# Altium schematic sheet Top.SchDoc
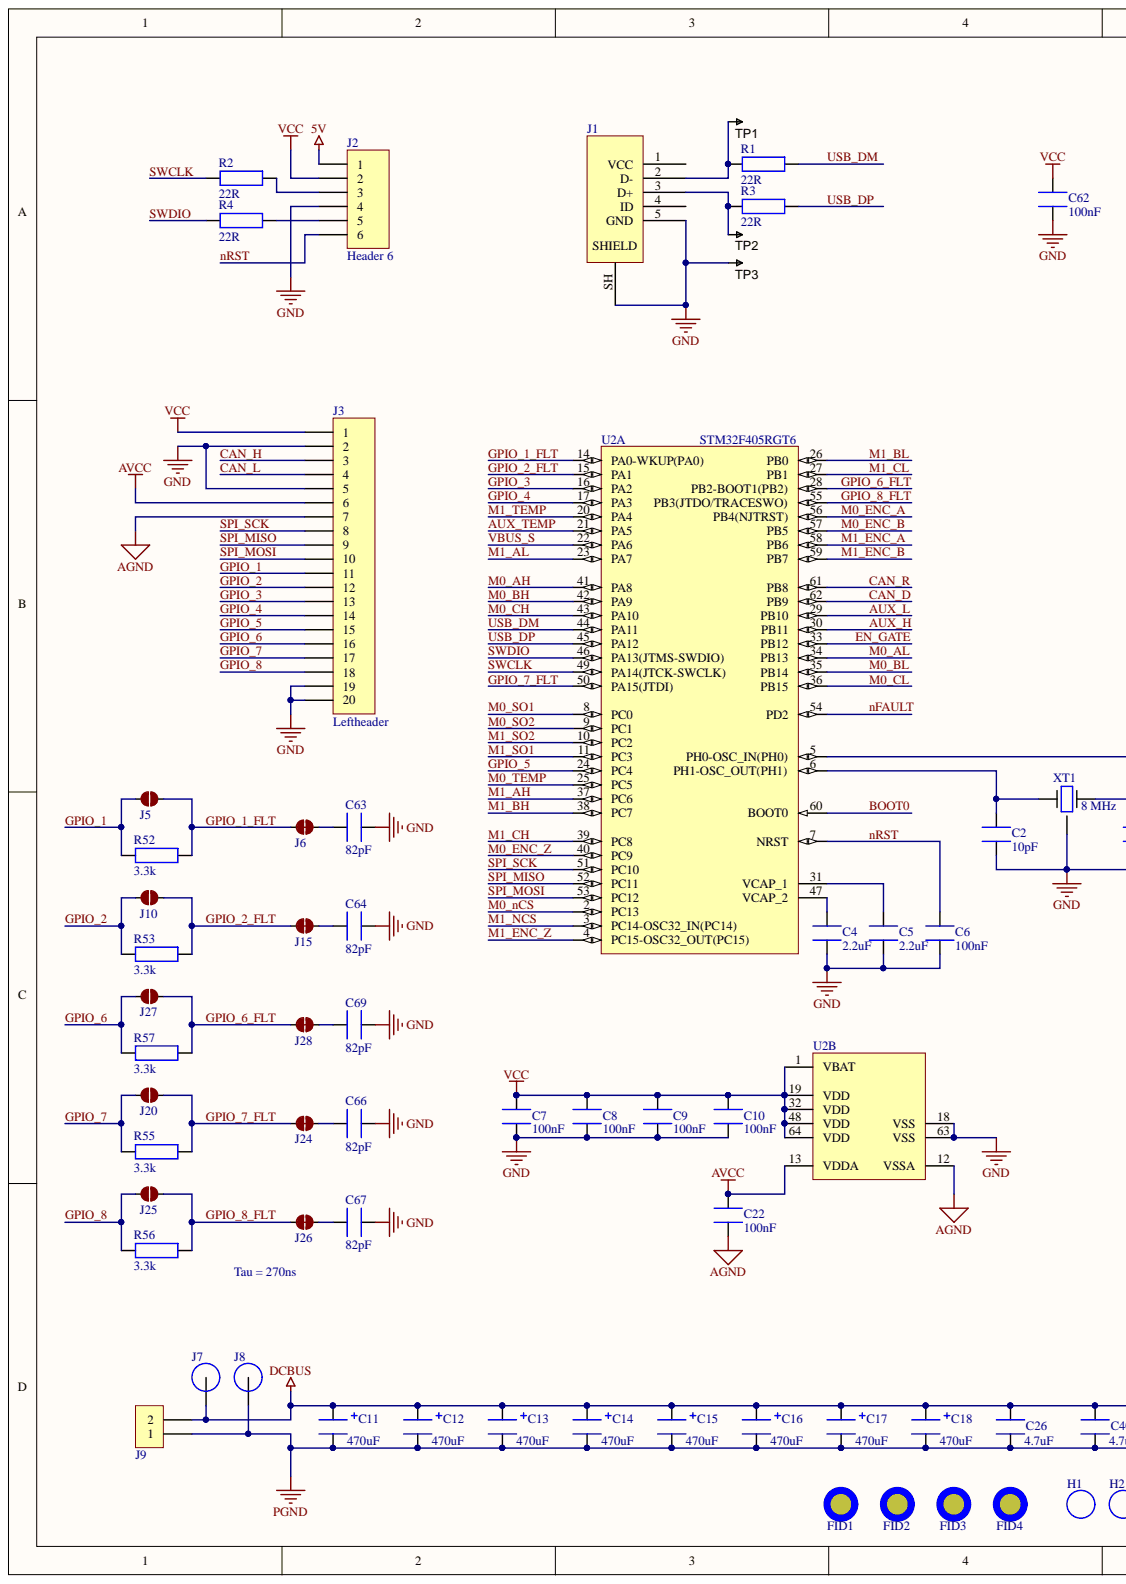
[diagram p0: Top.SchDoc, left]
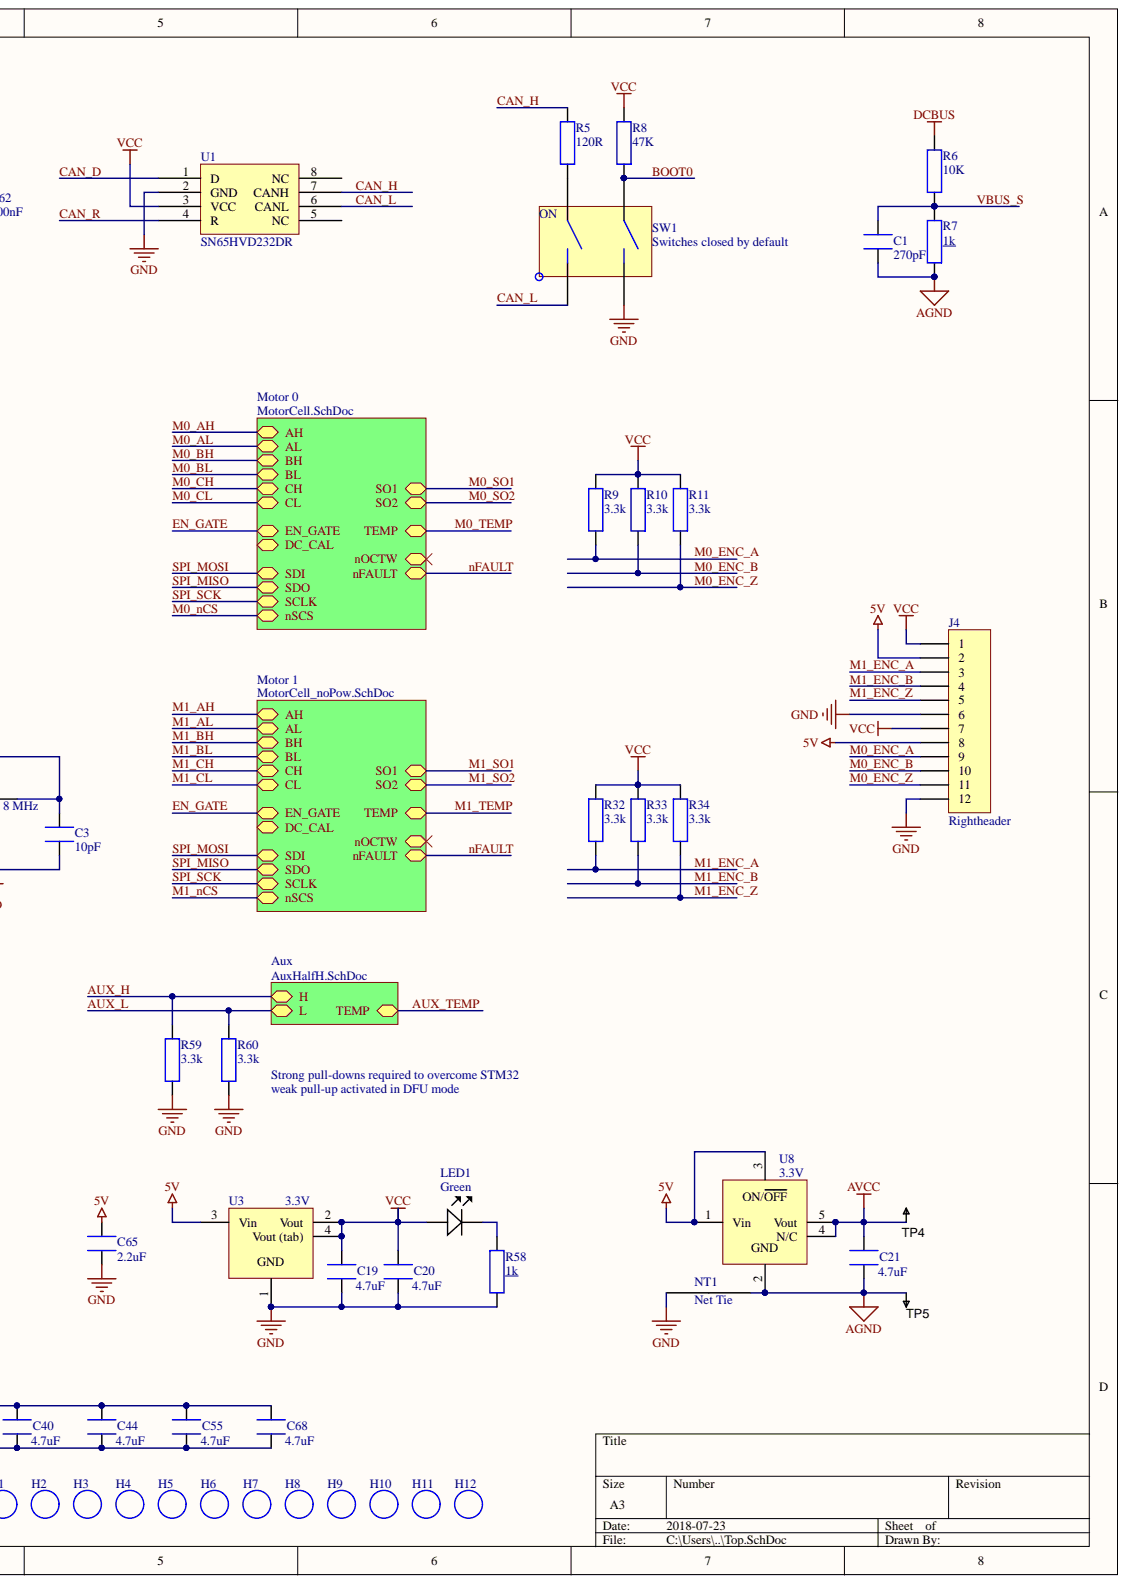
[diagram p1: Top.SchDoc, right]

## Parts
C1  270pF  [CAPC1608X09L]
C2  10pF  [CAPC1608X09L]
C3  10pF  [CAPC1608X09L]
C4  2.2uF  [CAPC1608X09L]
C5  2.2uF  [CAPC1608X09L]
C6  100nF  [CAPC1608X09L]
C7  100nF  [CAPC1608X09L]
C8  100nF  [CAPC1608X09L]
C9  100nF  [CAPC1608X09L]
C10  100nF  [CAPC1608X09L]
C11  470uF  [CAP_ELEC_SIZE_G]
C11  120uF  [CAP_ELEC_SIZE_G]  (value not drawn)
C12  470uF  [CAP_ELEC_SIZE_G]
C12  120uF  [CAP_ELEC_SIZE_G]  (value not drawn)
C13  470uF  [CAP_ELEC_SIZE_G]
C13  120uF  [CAP_ELEC_SIZE_G]  (value not drawn)
C14  470uF  [CAP_ELEC_SIZE_G]
C14  120uF  [CAP_ELEC_SIZE_G]  (value not drawn)
C15  470uF  [CAP_ELEC_SIZE_G]
C15  120uF  [CAP_ELEC_SIZE_G]  (value not drawn)
C16  470uF  [CAP_ELEC_SIZE_G]
C16  120uF  [CAP_ELEC_SIZE_G]  (value not drawn)
C17  470uF  [CAP_ELEC_SIZE_G]
C17  120uF  [CAP_ELEC_SIZE_G]  (value not drawn)
C18  470uF  [CAP_ELEC_SIZE_G]
C18  120uF  [CAP_ELEC_SIZE_G]  (value not drawn)
C19  4.7uF  [CAPC2012X09N]
C19  value 4.7uF  [CAPC2012X09N]  (hidden comment: GRM21BR61H475KE51L)
C20  4.7uF  [CAPC2012X09N]
C20  value 4.7uF  [CAPC2012X09N]  (hidden comment: GRM21BR61H475KE51L)
C21  4.7uF  [CAPC2012X09N]
C21  value 4.7uF  [CAPC2012X09N]  (hidden comment: GRM21BR61H475KE51L)
C22  100nF  [CAPC1608X09L]
C26  4.7uF  [CAPC2012X09N]
C26  value 4.7uF  [CAPC2012X09N]  (hidden comment: GRM21BR61H475KE51L)
C40  4.7uF  [CAPC2012X09N]
C40  value 4.7uF  [CAPC2012X09N]  (hidden comment: GRM21BR61H475KE51L)
C44  4.7uF  [CAPC2012X09N]
C44  value 4.7uF  [CAPC2012X09N]  (hidden comment: GRM21BR61H475KE51L)
C55  4.7uF  [CAPC2012X09N]
C55  value 4.7uF  [CAPC2012X09N]  (hidden comment: GRM21BR61H475KE51L)
C62  100nF  [CAPC1608X09L]
C63  82pF  [CAPC1608X09L]
C64  82pF  [CAPC1608X09L]
C65  2.2uF  [CAPC1608X09L]
C66  82pF  [CAPC1608X09L]
C67  82pF  [CAPC1608X09L]
C68  4.7uF  [CAPC2012X09N]
C68  value 4.7uF  [CAPC2012X09N]  (hidden comment: GRM21BR61H475KE51L)
C69  82pF  [CAPC1608X09L]
FID1  FIDUCIAL  [FIDUCIAL]  (value not drawn)
FID2  FIDUCIAL  [FIDUCIAL]  (value not drawn)
FID3  FIDUCIAL  [FIDUCIAL]  (value not drawn)
FID4  FIDUCIAL  [FIDUCIAL]  (value not drawn)
H1  (no value)  [M3 Hole]  (no BOM)
H2  (no value)  [M3 Hole]  (no BOM)
H3  (no value)  [M3 Hole]  (no BOM)
H4  (no value)  [M3 Hole]  (no BOM)
H5  (no value)  [M3 Hole]  (no BOM)
H6  (no value)  [M3 Hole]  (no BOM)
H7  (no value)  [M3 Hole]  (no BOM)
H8  (no value)  [M3 Hole]  (no BOM)
H9  (no value)  [M3 Hole]  (no BOM)
H10  (no value)  [M3 Hole]  (no BOM)
H11  (no value)  [M3 Hole]  (no BOM)
H12  (no value)  [M3 Hole]  (no BOM)
J1  (no value)  [USB_0103594-0001LF]
J2  Header 6  [HDR1X6]
J3  Leftheader  [HDR1X20]
J4  Rightheader  [HDR1X12]
J5  2 way net solder junction - Normally Open  [2waySolder_NO]  (value not drawn)  (no BOM)
J6  2 way net solder junction - Shorted  [2waySolder_SH]  (value not drawn)
J7  (no value)  [M3 Spade Hole]  (no BOM)
J8  (no value)  [M3 Spade Hole]  (no BOM)
J9  1868076  [1868076]  (value not drawn)
J10  2 way net solder junction - Normally Open  [2waySolder_NO]  (value not drawn)  (no BOM)
J15  2 way net solder junction - Shorted  [2waySolder_SH]  (value not drawn)
J20  2 way net solder junction - Normally Open  [2waySolder_NO]  (value not drawn)  (no BOM)
J24  2 way net solder junction - Shorted  [2waySolder_SH]  (value not drawn)
J25  2 way net solder junction - Normally Open  [2waySolder_NO]  (value not drawn)  (no BOM)
J26  2 way net solder junction - Shorted  [2waySolder_SH]  (value not drawn)
J27  2 way net solder junction - Normally Open  [2waySolder_NO]  (value not drawn)  (no BOM)
J28  2 way net solder junction - Shorted  [2waySolder_SH]  (value not drawn)
LED1  Green  [LED-0603-GREEN]
NT1  (no value)  [NetTie_2mm]
R1  22R  [RESC1608X55N]
R2  22R  [RESC1608X55N]
R3  22R  [RESC1608X55N]
R4  22R  [RESC1608X55N]
R5  120R  [RESC1608X55N]
R6  10K  [RESC1608X55N]
R6  18k  [RESC1608X55N]  (value not drawn)
R7  1k  [RESC1608X55N]
R8  47K  [RESC1608X55N]
R9  3.3k  [RESC1608X55N]
R10  3.3k  [RESC1608X55N]
R11  3.3k  [RESC1608X55N]
R32  3.3k  [RESC1608X55N]
R33  3.3k  [RESC1608X55N]
R34  3.3k  [RESC1608X55N]
R52  3.3k  [RESC1608X55N]
R53  3.3k  [RESC1608X55N]
R55  3.3k  [RESC1608X55N]
R56  3.3k  [RESC1608X55N]
R57  3.3k  [RESC1608X55N]
R58  1k  [RESC1608X55N]
R59  3.3k  [RESC1608X55N]
R60  3.3k  [RESC1608X55N]
SW1  Category: Switches  [SOP254P990X405-4N]  (hidden comment: 219-2MSTR)
TP1  TESTPOINT  [TP-R60]  (value not drawn)  (no BOM)
TP2  TESTPOINT  [TP-R60]  (value not drawn)  (no BOM)
TP3  TESTPOINT  [TP-R60]  (value not drawn)  (no BOM)
TP4  TESTPOINT  [TP-R30]  (value not drawn)  (no BOM)
TP5  TESTPOINT  [TP-R30]  (value not drawn)  (no BOM)
U1  SN65HVD232D  Manufacturer Part Number: SN65HVD232DR  [SOIC127P600X175-8N]
U2  (no value)  [STM-LQFP64_N]  (parts A,B)
U3  3.3V  [SOT223]
U8  3.3V  [SOT23-5]
XT1  8 MHz  Load Capacitance: 10pF  [DFN220P320X110-4N]

## Nets
5V: C65.2 J2.1 J4.2 J4.8 U3.3(Vin) U8.1(Vin) U8.3
AGND: C1.1 C21.1 C22.2 J3.7 NT1.2 R7.1 TP5.1 U2.12(VSSA) U8.2
AVCC: C21.2 C22.1 J3.6 TP4.1 U2.13(VDDA) U8.4(N/C) U8.5(Vout)
DCBUS: C11.1 C12.1 C13.1 C14.1 C15.1 C16.1 C17.1 C18.1 C26.2 C40.2 C44.2 C55.2 C68.2 J7.1 J9.2 R6.2
GND: C2.2 C3.2 C4.1 C5.1 C6.1 C7.2 C8.2 C9.2 C10.2 C19.1 C20.1 C62.2 C63.2 C64.2 C65.1 C66.2 C67.2 C69.2 J1.5(GND) J1.SH J2.4 J3.2 J3.5 J3.19 J3.20 J4.6 J4.12 NT1.1 R58.2 R59.2 R60.2 SW1.2 TP3.1 U1.2(GND) U2.18(VSS) U2.63(VSS) U3.1 XT1.G
PGND: C11.2 C12.2 C13.2 C14.2 C15.2 C16.2 C17.2 C18.2 C26.1 C40.1 C44.1 C55.1 C68.1 J8.1 J9.1
VCC: C7.1 C8.1 C9.1 C10.1 C19.2 C20.2 C62.1 J2.2 J3.1 J4.1 J4.7 LED1.A R8.2 R9.2 R10.2 R11.2 R32.1 R33.1 R34.1 U1.3(VCC) U2.1(VBAT) U2.19(VDD) U2.32(VDD) U2.48(VDD) U2.64(VDD) U3.2(Vout) U3.4(Vout_(tab))
AUX_H: R59.1 U2.30(PB11)
AUX_L: R60.1 U2.29(PB10)
AUX_TEMP: U2.21(PA5)
BOOT0: R8.1 SW1.3 U2.60(BOOT0)
CAN_D: U1.1(D) U2.62(PB9)
CAN_H: J3.3 R5.1 U1.7(CANH)
CAN_L: J3.4 SW1.1 U1.6(CANL)
CAN_R: U1.4(R) U2.61(PB8)
EN_GATE: U2.33(PB12)
GPIO_1: J3.11 J5.1 R52.2
GPIO_1_FLT: J5.2 J6.2 R52.1 U2.14(PA0-WKUP(PA0))
GPIO_2: J3.12 J10.1 R53.2
GPIO_2_FLT: J10.2 J15.2 R53.1 U2.15(PA1)
GPIO_3: J3.13 U2.16(PA2)
GPIO_4: J3.14 U2.17(PA3)
GPIO_5: J3.15 U2.24(PC4)
GPIO_6: J3.16 J27.1 R57.2
GPIO_6_FLT: J27.2 J28.2 R57.1 U2.28(PB2-BOOT1(PB2))
GPIO_7: J3.17 J20.1 R55.2
GPIO_7_FLT: J20.2 J24.2 R55.1 U2.50(PA15(JTDI))
GPIO_8: J3.18 J25.1 R56.2
GPIO_8_FLT: J25.2 J26.2 R56.1 U2.55(PB3(JTDO/TRACESWO))
M0_AH: U2.41(PA8)
M0_AL: U2.34(PB13)
M0_BH: U2.42(PA9)
M0_BL: U2.35(PB14)
M0_CH: U2.43(PA10)
M0_CL: U2.36(PB15)
M0_ENC_A: J4.9 R9.1 U2.56(PB4(NJTRST))
M0_ENC_B: J4.10 R10.1 U2.57(PB5)
M0_ENC_Z: J4.11 R11.1 U2.40(PC9)
M0_NCS: U2.2(PC13)
M0_SO1: U2.8(PC0)
M0_SO2: U2.9(PC1)
M0_TEMP: U2.25(PC5)
M1_AH: U2.37(PC6)
M1_AL: U2.23(PA7)
M1_BH: U2.38(PC7)
M1_BL: U2.26(PB0)
M1_CH: U2.39(PC8)
M1_CL: U2.27(PB1)
M1_ENC_A: J4.3 R32.2 U2.58(PB6)
M1_ENC_B: J4.4 R33.2 U2.59(PB7)
M1_ENC_Z: J4.5 R34.2 U2.4(PC15-OSC32_OUT(PC15))
M1_NCS: U2.3(PC14-OSC32_IN(PC14))
M1_SO1: U2.11(PC3)
M1_SO2: U2.10(PC2)
M1_TEMP: U2.20(PA4)
NFAULT: U2.54(PD2)
NRST: C6.2 J2.6 U2.7(NRST)
SPI_MISO: J3.9 U2.52(PC11)
SPI_MOSI: J3.10 U2.53(PC12)
SPI_SCK: J3.8 U2.51(PC10)
SWCLK: R2.2 U2.49(PA14(JTCK-SWCLK))
SWDIO: R4.2 U2.46(PA13(JTMS-SWDIO))
USB_DM: R1.1 U2.44(PA11)
USB_DP: R3.1 U2.45(PA12)
VBUS_S: C1.2 R6.1 R7.2 U2.22(PA6)
NetC2_1: C2.1 U2.6(PH1-OSC_OUT(PH1)) XT1.1
NetC3_1: C3.1 U2.5(PH0-OSC_IN(PH0)) XT1.3
NetC4_2: C4.2 U2.47(VCAP_2)
NetC5_2: C5.2 U2.31(VCAP_1)
NetC63_1: C63.1 J6.1
NetC64_1: C64.1 J15.1
NetC66_1: C66.1 J24.1
NetC67_1: C67.1 J26.1
NetC69_1: C69.1 J28.1
NetJ1_1: J1.1(VCC)
NetJ1_2: J1.2(D-) R1.2 TP1.1
NetJ1_3: J1.3(D+) R3.2 TP2.1
NetJ1_4: J1.4(ID)
NetJ2_3: J2.3 R2.1
NetJ2_5: J2.5 R4.1
NetLED1_K: LED1.K R58.1
NetR5_2: R5.2 SW1.4
NetU1_5: U1.5(NC)
NetU1_8: U1.8(NC)
N00188:
N00189:
N00190:
N00191:

## Library data of the parts
C1 = GRM1885C1H271JA01D: CAP CER 270PF 50V NP0 0603; Manufacturer: Murata Electronics North America; Temperature Coefficient: C0G, NP0; Tolerance: ±5%; Applications: General Purpose; Capacitance: 270pF; Category: Capacitors; Component Kind: Standard; ComponentLink1Description: http://www.murata.com/~/media/webrenewal/support/library/catalog/products/capacitor/mlcc/c02e.pdf; ComponentLink1URL: http://www.murata.com/~/media/webrenewal/support/library/catalog/products/capacitor/mlcc/c02e.pdf; Features: -; Height - Seated (Max): -; Lead Spacing: -; Lead Style: -; Library Name: CustomComponents.SchLib; Manufacturer Part Number: GRM1885C1H271JA01D; Mounting Type: Surface Mount, MLCC; Operating Temperature: -55°C ~ 125°C; Package / Case: 0603 (1608 Metric); Packaging: Cut Tape (CT); Pricing 1: 1=0.1, 10=0.048, 50=0.026, 100=0.022, 250=0.018, 500=0.0154, 1000=0.012 (USD); Ratings: -; RoHS: RoHS Compliant; Size / Dimension: 0.063" L x 0.031" W (1.60mm x 0.80mm); Stock 1: 46048; Supplier 1: Digi-Key; Supplier Part Number 1: 490-1437-1-ND; Thickness (Max): 0.035" (0.90mm); Voltage - Rated: 50V
C2 C3 = GRM1885C1H100JA01D: CAP CER 10PF 50V NP0 0603; Manufacturer: Murata Electronics North America; Temperature Coefficient: C0G, NP0; Tolerance: ±5%; Applications: General Purpose; Capacitance: 10pF; Category: Capacitors; Component Kind: Standard; ComponentLink1Description: http://www.murata.com/~/media/webrenewal/support/library/catalog/products/capacitor/mlcc/c02e.pdf; ComponentLink1URL: http://www.murata.com/~/media/webrenewal/support/library/catalog/products/capacitor/mlcc/c02e.pdf; Features: -; Height - Seated (Max): -; Lead Spacing: -; Lead Style: -; Library Name: CustomComponents.SchLib; Manufacturer Part Number: GRM1885C1H100JA01D; Mounting Type: Surface Mount, MLCC; Operating Temperature: -55°C ~ 125°C; Package / Case: 0603 (1608 Metric); Packaging: Cut Tape (CT); Pricing 1: 1=0.1, 10=0.041, 50=0.0222, 100=0.0187, 250=0.01532, 500=0.0131, 1000=0.0102 (USD); Ratings: -; RoHS: RoHS Compliant; Size / Dimension: 0.063" L x 0.031" W (1.60mm x 0.80mm); Stock 1: 450321; Supplier 1: Digi-Key; Supplier Part Number 1: 490-1403-1-ND; Thickness (Max): 0.035" (0.90mm); Voltage - Rated: 50V
C4 C5 C65 = EMK107BJ225KA-T: CAP CER 2.2UF 16V X5R 0603; Manufacturer: Taiyo Yuden; Notification: Current market demand for these product types have resulted in fluctuating and extending lead times. Lead times may differ.; Tolerance: ±10%; Applications: General Purpose; Capacitance: 2.2uF; Category: Capacitors; Component Kind: Standard; ComponentLink1Description: http://ds.yuden.co.jp/TYCOMPAS/ut/detail.do?productNo=EMK107BJ225KA-T&fileName=EMK107BJ225KA-T_SS&mode=specSheetDownload; ComponentLink1URL: http://ds.yuden.co.jp/TYCOMPAS/ut/detail.do?productNo=EMK107BJ225KA-T&fileName=EMK107BJ225KA-T_SS&mode=specSheetDownload; Features: -; Height - Seated (Max): -; Lead Spacing: -; Lead Style: -; Library Name: CustomComponents.SchLib; Mounting Type: Surface Mount, MLCC; Operating Temperature: -55°C ~ 85°C; Package / Case: 0603 (1608 Metric); Packaging: Cut Tape (CT); Part Status: Active; Pricing 1: 1=0.12, 10=0.089, 100=0.0396, 500=0.02826, 1000=0.0222 (USD); Ratings: -; RoHS: RoHS Compliant; Size / Dimension: 0.063" L x 0.032" W (1.60mm x 0.80mm); Stock: 1577703; Supplier 1: Digi-Key; Supplier Part Number 1: 587-1430-1-ND; Temperature Coefficient: X5R; Thickness (Max): 0.035" (0.90mm); Voltage - Rated: 16V
C6-C10 C22 C62 = GCM188R91E104KA37D: CAP CER 0.1UF 25V X8R 0603; Manufacturer: Murata Electronics North America; Tolerance: ±10%; Applications: Automotive; Capacitance: 100nF; Category: Capacitors; Component Kind: Standard; ComponentLink1Description: http://psearch.en.murata.com/capacitor/product/GCM188R91E104KA37#.pdf; ComponentLink1URL: http://psearch.en.murata.com/capacitor/product/GCM188R91E104KA37#.pdf; Failure Rate: -; Features: -; Height - Seated (Max): -; Lead Spacing: -; Lead Style: -; Library Name: CustomComponents.SchLib; Mounting Type: Surface Mount, MLCC; Operating Temperature: -55°C ~ 150°C; Package / Case: 0603 (1608 Metric); Packaging: Cut Tape (CT); Part Status: Active; Pricing 1: 1=0.21, 10=0.142, 100=0.0719, 500=0.04918, 1000=0.0435 (USD); Ratings: AEC-Q200; RoHS: RoHS Compliant; Size / Dimension: 0.063" L x 0.032" W (1.60mm x 0.80mm); Stock: 6538; Supplier 1: Digi-Key; Supplier Part Number 1: 490-14359-1-ND; Temperature Coefficient: X8R; Thickness (Max): 0.035" (0.90mm); Voltage - Rated: 25V
C11-C18 = EEEFP1E471AP: CAP, FP Series, 470 µF, 25 V, Radial Can - SMD, 10 mm; Manufacturer: Panasonic Electronic Components; Manufacturer Part Number: EEE-FP1E471AP; ESR (Equivalent Series Resistance): 60 mOhm @ 100kHz; Lifetime @ Temp.: 2000 Hrs @ 105°C; Polarization: Polar; Ripple Current - High Frequency: 1.19A @ 100kHz; Ripple Current - Low Frequency: 773.5mA @ 120Hz; Surface Mount Land Size: 0.406" L x 0.406" W (10.30mm x 10.30mm); Tolerance: ±20%; Applications: Automotive; Category: Capacitors; Component Kind: Standard; ComponentLink1Description: http://industrial.panasonic.com/www-cgi/jvcr13pz.cgi?E+PZ+3+ABA0114+EEEFP1E471AP+7+WW; ComponentLink1URL: http://industrial.panasonic.com/www-cgi/jvcr13pz.cgi?E+PZ+3+ABA0114+EEEFP1E471AP+7+WW; Height - Seated (Max): 0.402" (10.20mm); Lead Spacing: -; Library Name: CustomComponents.SchLib; Mounting Type: Surface Mount; Operating Temperature: -55°C ~ 105°C; Package / Case: Radial, Can - SMD; Packaging: Tape & Reel (TR); Part Status: Active; Pricing 1: 500=0.3571, 1000=0.30072, 2500=0.28192, 5000=0.26313, 12500=0.24997, 25000=0.24434 (USD); Pricing 2: 1=0.92, 10=0.702, 100=0.5025 (USD); RoHS: RoHS Compliant; Size / Dimension: 0.394" Dia (10.00mm); Stock: 8000; Supplier 1: Digi-Key; Supplier 2: Digi-Key; Supplier Part Number 1: PCE4440TR-ND; Supplier Part Number 2: PCE4440CT-ND; Value: 470uF
C11-C18 = EEH-ZC1H121P: CAP ALUM POLY HYB 120UF 50V SMD; Manufacturer: Panasonic Electronic Components; ESR (Equivalent Series Resistance): 28 mOhm; Lifetime @ Temp.: 4000 Hrs @ 125°C; Ripple Current - High Frequency: 1.6A @ 100kHz; Ripple Current - Low Frequency: 240mA @ 100Hz; Surface Mount Land Size: 0.406" L x 0.406" W (10.30mm x 10.30mm); Tolerance: ±20%; Type: Hybrid; Applications: Automotive; Capacitance: 120uF; Category: Capacitors; Component Kind: Standard; ComponentLink1Description: https://industrial.panasonic.com/cdbs/www-data/pdf/RDD0000/ABA0000C1234.pdf; ComponentLink1URL: https://industrial.panasonic.com/cdbs/www-data/pdf/RDD0000/ABA0000C1234.pdf; Height - Seated (Max): 0.413" (10.50mm); Lead Spacing: -; Library Name: CustomComponents.SchLib; Manufacturer Part Number: EEH-ZC1H121P; Mounting Type: Surface Mount; Operating Temperature: -55°C ~ 125°C; Package / Case: Radial, Can - SMD; Packaging: Cut Tape (CT); Part Status: Active; Pricing 1: 1=2.18, 10=1.761, 100=1.345 (USD); Pricing 2: 500=0.89108, 1000=0.77969, 2500=0.75184, 5000=0.724, 12500=0.72134 (USD); RoHS: RoHS Compliant; Size / Dimension: 0.394" Dia (10.00mm); Stock: 1939; Supplier 1: Digi-Key; Supplier 2: Digi-Key; Supplier Part Number 1: P19316CT-ND; Supplier Part Number 2: P19316TR-ND
C19-C21 C26 C40 C44 C55 C68 = GRM219R6YA475KA73D: CAP CER 4.7UF 35V X5R 0805; Manufacturer: Murata Electronics North America; Tolerance: ±10%; Applications: General Purpose; Category: Capacitors; Component Kind: Standard; ComponentLink1Description: http://www.murata.com/~/media/webrenewal/support/library/catalog/products/capacitor/mlcc/c02e.ashx?la=en-us; ComponentLink1URL: http://www.murata.com/~/media/webrenewal/support/library/catalog/products/capacitor/mlcc/c02e.ashx?la=en-us; Features: Derating Recommended; Height - Seated (Max): -; Lead Spacing: -; Lead Style: -; Library Name: CustomComponents.SchLib; Manufacturer Part Number: GRM219R6YA475KA73D; Mounting Type: Surface Mount, MLCC; Operating Temperature: -55°C ~ 85°C; Package / Case: 0805 (2012 Metric); Packaging: Tape & Reel (TR); Part Status: Active; Pricing 1: 4000=0.05126, 8000=0.0487, 12000=0.04613 (USD); Pricing 2: 1=0.26, 10=0.177, 100=0.1002, 500=0.07076, 1000=0.06191 (USD); Ratings: -; RoHS: RoHS Compliant; Size / Dimension: 0.079" L x 0.049" W (2.01mm x 1.25mm); Stock: 152000; Supplier 1: Digi-Key; Supplier 2: Digi-Key; Supplier Part Number 1: 490-7208-2-ND; Supplier Part Number 2: 490-7208-1-ND; Temperature Coefficient: X5R; Thickness (Max): 0.037" (0.95mm); Value: 4.7uF; Voltage - Rated: 35V
C19-C21 C26 C40 C44 C55 C68 = GRM21BR61H475KE51L: CAP CER 4.7UF 50V X5R 0805; Manufacturer: Murata Electronics North America; Tolerance: ±10%; Applications: General Purpose; Category: Capacitors; Component Kind: Standard; ComponentLink1Description: http://search.murata.co.jp/Ceramy/image/img/A01X/G101/ENG/GRM21BR61H475KE51-01.pdf; ComponentLink1URL: http://search.murata.co.jp/Ceramy/image/img/A01X/G101/ENG/GRM21BR61H475KE51-01.pdf; Features: -; Height - Seated (Max): -; Lead Spacing: -; Lead Style: -; Library Name: CustomComponents.SchLib; Manufacturer Part Number: GRM21BR61H475KE51L; Mounting Type: Surface Mount, MLCC; Operating Temperature: -55°C ~ 85°C; Package / Case: 0805 (2012 Metric); Packaging: Tape & Reel (TR); Part Status: Active; Pricing 1: 3000=0.08404, 6000=0.07774, 9000=0.07354 (USD); Pricing 2: 1=0.35, 10=0.242, 100=0.145, 500=0.10872, 1000=0.09181 (USD); Ratings: -; RoHS: RoHS Compliant; Size / Dimension: 0.079" L x 0.049" W (2.00mm x 1.25mm); Stock: 15000; Supplier 1: Digi-Key; Supplier 2: Digi-Key; Supplier Part Number 1: 490-10751-2-ND; Supplier Part Number 2: 490-10751-1-ND; Temperature Coefficient: X5R; Thickness (Max): 0.055" (1.40mm); Voltage - Rated: 50V
C63 C64 C66 C67 C69 = GRM1885C2A820JA01D: CAP CER 82PF 100V NP0 0603; Manufacturer: Murata Electronics North America; Temperature Coefficient: C0G, NP0; Tolerance: ±5%; Applications: General Purpose; Capacitance: 82pF; Category: Capacitors; Component Kind: Standard; ComponentLink1Description: http://www.murata.com/~/media/webrenewal/support/library/catalog/products/capacitor/mlcc/c02e.pdf; ComponentLink1URL: http://www.murata.com/~/media/webrenewal/support/library/catalog/products/capacitor/mlcc/c02e.pdf; Features: -; Height - Seated (Max): -; Lead Spacing: -; Lead Style: -; Library Name: CustomComponents.SchLib; Manufacturer Part Number: GRM1885C2A820JA01D; Mounting Type: Surface Mount, MLCC; Operating Temperature: -55°C ~ 125°C; Package / Case: 0603 (1608 Metric); Packaging: Cut Tape (CT); Pricing 1: 1=0.1, 10=0.052, 50=0.028, 100=0.0237, 250=0.01936, 500=0.01656, 1000=0.0129 (USD); Ratings: -; RoHS: RoHS Compliant; Size / Dimension: 0.063" L x 0.031" W (1.60mm x 0.80mm); Stock 1: 58970; Supplier 1: Digi-Key; Supplier Part Number 1: 490-1349-1-ND; Thickness (Max): 0.035" (0.90mm); Voltage - Rated: 100V
FID1-FID4 = FIDUCIAL: 1mm Fiducial with 2mm soldermask, 3mm keepout; Component Kind: Standard; Library Name: CustomComponents.SchLib
H1-H12 = M3 Hole: M3 Hole with no copper; Library Name: CustomComponents.SchLib
J1 = 10103594-0001LF: MICRO USB B, RECEPTACLE, SMT, RA, 5WAY; Component Kind: Standard; Library Name: CustomComponents.SchLib; Supplier 1: Farnell; Supplier Part Number 1: 2293752
J2 = Header 6: Header, 6-Pin; Component Kind: Standard; LatestRevisionDate: 17-Jul-2002; LatestRevisionNote: Re-released for DXP Platform.; Library Name: Miscellaneous Connectors.IntLib; Signal Integrity: Connector; Publisher: Altium Limited
J3 = Header 20: Header, 20-Pin; Component Kind: Standard; LatestRevisionDate: 17-Jul-2002; LatestRevisionNote: Re-released for DXP Platform.; Library Name: Miscellaneous Connectors.IntLib; Signal Integrity: Connector; Publisher: Altium Limited
J4 = Header 12: Header, 12-Pin; Component Kind: Standard; LatestRevisionDate: 17-Jul-2002; LatestRevisionNote: Re-released for DXP Platform.; Library Name: Miscellaneous Connectors.IntLib; Signal Integrity: Connector; Publisher: Altium Limited
J5 J10 J20 J25 J27 = 2waySolderNO: ComponentLink1Description: Source; ComponentLink1URL: http://www.edatools.cz/addons; Description: 2 way net solder junction - Normally Open; Library Name: CustomComponents.SchLib
J6 J15 J24 J26 J28 = 2waySolderSH: Component Kind: Net Tie; ComponentLink1Description: Source; ComponentLink1URL: http://www.edatools.cz/addons; Description: 2 way net solder junction - Shorted; Library Name: CustomComponents.SchLib
J7 J8 = M3 Spade Terminal: Description: M3 Spade Terminal; Library Name: CustomComponents.SchLib
J9 = 1868076: CONN TERM BLOCK 2POS 7.62MM; Manufacturer: Phoenix Contact; Current: 30A; Mating Orientation: Horizontal with Board; Number of Levels: 1; Pitch: 0.300" (7.62mm); Positions Per Level: 2; Screw Thread: M3; Torque - Screw: 0.5-0.6 Nm (4.4-5.3 Lb-In); Voltage: 300V; Wire Gauge: 10-30 AWG; Wire Termination: Screw - Rising Cage Clamp; Category: Connectors, Interconnects; Clamp Material - Plating: -; Color: Green; Component Kind: Standard; ComponentLink1Description: http://media.digikey.com/PDF/Data%20Sheets/Phoenix%20Contact%20PDFs/1868076.pdf; ComponentLink1URL: http://media.digikey.com/PDF/Data%20Sheets/Phoenix%20Contact%20PDFs/1868076.pdf; Contact Material - Plating: -; Features: Interlocking (Side); Housing Material: Polyamide (PA), Nylon; Library Name: CustomComponents.SchLib; Mounting Type: Through Hole; Operating Temperature: -; Packaging: Bulk; Part Status: Active; Pricing 1: 1=1.56, 10=1.517, 50=1.4178, 100=1.3044, 250=1.20512, 500=1.14842, 1000=1.0564 (USD); RoHS: RoHS Compliant; Screw Material - Plating: -; Stock: 2175; Supplier 1: Digi-Key; Supplier Part Number 1: 277-5840-ND
LED1 = LTST-C190KGKT: LED GREEN CLEAR 0603 SMD; Manufacturer: Lite-On Inc.; Current - Test: 20mA; Lens Color: Colorless; Lens Style/Size: Rectangle with Flat Top, 1.10mm x 0.80mm; Millicandela Rating: 35mcd; Viewing Angle: 130°; Voltage - Forward (Vf) (Typ): 2V; Wavelength - Dominant: 571nm; Wavelength - Peak: 574nm; Category: Optoelectronics; Color: Green; Component Kind: Standard; ComponentLink1Description: http://optoelectronics.liteon.com/upload/download/DS22-2000-074/S_110_LTST-C190KGKT.pdf; ComponentLink1URL: http://optoelectronics.liteon.com/upload/download/DS22-2000-074/S_110_LTST-C190KGKT.pdf; Features: -; Height (Max): 0.80mm; Lens Transparency: Clear; Library Name: CustomComponents.SchLib; Manufacturer Part Number: LTST-C190KGKT; Mounting Type: Surface Mount; Package / Case: 0603 (1608 Metric); Packaging: Cut Tape (CT); Part Status: Active; Pricing 1: 1=0.27, 10=0.196, 25=0.1448, 100=0.1034, 250=0.07032, 500=0.06204, 1000=0.0486 (USD); RoHS: RoHS Compliant; Size / Dimension: 1.60mm L x 0.80mm W; Stock: 922756; Supplier 1: Digi-Key; Supplier Part Number 1: 160-1435-1-ND
NT1 = NetTie_2mm: Component Kind: Net Tie; Library Name: CustomComponents.SchLib
R1-R4 = RC0603FR-0722RL: RES SMD 22 OHM 1% 1/10W 0603; Manufacturer: Yageo; Power (Watts): 0.1W, 1/10W; Resistance (Ohms): 22; Temperature Coefficient: ±100ppm/°C; Category: Resistors; Component Kind: Standard; ComponentLink1Description: http://www.yageo.com/documents/recent/PYu-RC_Group_51_RoHS_L_04.pdf; ComponentLink1URL: http://www.yageo.com/documents/recent/PYu-RC_Group_51_RoHS_L_04.pdf; Composition: Thick Film; Features: Moisture Resistant; Height: 0.022" (0.55mm); Library Name: CustomComponents.SchLib; Manufacturer Part Number: RC0603FR-0722RL; Number of Terminations: 2; Operating Temperature: -55°C ~ 155°C; Package / Case: 0603 (1608 Metric); Packaging: Cut Tape (CT); Pricing 1: 1=0.1, 10=0.014, 25=0.01, 100=0.0057, 250=0.00436, 500=0.00348, 1000=0.00257, 2500=0.00223 (USD); RoHS: RoHS Compliant; Size / Dimension: 0.063" L x 0.031" W (1.60mm x 0.80mm); Stock 1: 575303; Supplier 1: Digi-Key; Supplier Device Package: 0603; Supplier Part Number 1: 311-22.0HRCT-ND; Tolerance: ±1%
R5 = RC0603FR-07120RL: RES SMD 120 OHM 1% 1/10W 0603; Manufacturer: Yageo; Power (Watts): 0.1W, 1/10W; Resistance (Ohms): 120; Temperature Coefficient: ±100ppm/°C; Category: Resistors; Component Kind: Standard; ComponentLink1Description: http://www.yageo.com/documents/recent/PYu-RC_Group_51_RoHS_L_04.pdf; ComponentLink1URL: http://www.yageo.com/documents/recent/PYu-RC_Group_51_RoHS_L_04.pdf; Composition: Thick Film; Features: Moisture Resistant; Height: 0.022" (0.55mm); Library Name: CustomComponents.SchLib; Manufacturer Part Number: RC0603FR-07120RL; Number of Terminations: 2; Operating Temperature: -55°C ~ 155°C; Package / Case: 0603 (1608 Metric); Packaging: Cut Tape (CT); Pricing 1: 1=0.1, 10=0.014, 25=0.01, 100=0.0057, 250=0.00436, 500=0.00348, 1000=0.00257, 2500=0.00223 (USD); RoHS: RoHS Compliant; Size / Dimension: 0.063" L x 0.031" W (1.60mm x 0.80mm); Stock 1: 1545282; Supplier 1: Digi-Key; Supplier Device Package: 0603; Supplier Part Number 1: 311-120HRCT-ND; Tolerance: ±1%
R6 = RC0603FR-0710KL: RES SMD 10K OHM 1% 1/10W 0603; Manufacturer: Yageo; Power (Watts): 0.1W, 1/10W; Temperature Coefficient: ±100ppm/°C; Category: Resistors; Component Kind: Standard; ComponentLink1Description: http://www.yageo.com/documents/recent/PYu-RC_Group_51_RoHS_L_04.pdf; ComponentLink1URL: http://www.yageo.com/documents/recent/PYu-RC_Group_51_RoHS_L_04.pdf; Composition: Thick Film; Features: Moisture Resistant; Height: 0.022" (0.55mm); Library Name: CustomComponents.SchLib; Manufacturer Part Number: RC0603FR-0710KL; Number of Terminations: 2; Operating Temperature: -55°C ~ 155°C; Package / Case: 0603 (1608 Metric); Packaging: Cut Tape (CT); Pricing 1: 1=0.1, 10=0.014, 25=0.01, 100=0.0057, 250=0.00436, 500=0.00348, 1000=0.00257, 2500=0.00223 (USD); Resistance (Ohms): 10k; RoHS: RoHS Compliant; Size / Dimension: 0.063" L x 0.031" W (1.60mm x 0.80mm); Stock 1: 13504930; Supplier 1: Digi-Key; Supplier Device Package: 0603; Supplier Part Number 1: 311-10.0KHRCT-ND; Tolerance: ±1%
R6 = RC0603FR-0718KL: RES SMD 18K OHM 1% 1/10W 0603; Manufacturer: Yageo; Power (Watts): 0.1W, 1/10W; Temperature Coefficient: ±100ppm/°C; Category: Resistors; Component Kind: Standard; ComponentLink1Description: http://www.yageo.com/documents/recent/PYu-RC_Group_51_RoHS_L_04.pdf; ComponentLink1URL: http://www.yageo.com/documents/recent/PYu-RC_Group_51_RoHS_L_04.pdf; Composition: Thick Film; Features: Moisture Resistant; Height: 0.022" (0.55mm); Library Name: CustomComponents.SchLib; Manufacturer Part Number: RC0603FR-0718KL; Number of Terminations: 2; Operating Temperature: -55°C ~ 155°C; Package / Case: 0603 (1608 Metric); Packaging: Cut Tape (CT); Pricing 1: 1=0.1, 10=0.014, 25=0.01, 100=0.0057, 250=0.00436, 500=0.00348, 1000=0.00257, 2500=0.00223 (USD); Resistance (Ohms): 18k; RoHS: RoHS Compliant; Size / Dimension: 0.063" L x 0.031" W (1.60mm x 0.80mm); Stock 1: 0; Supplier 1: Digi-Key; Supplier Device Package: 0603; Supplier Part Number 1: 311-18.0KHRCT-ND; Tolerance: ±1%
R7 R58 = RC0603FR-071KL: RES SMD 1K OHM 1% 1/10W 0603; Manufacturer: Yageo; Power (Watts): 0.1W, 1/10W; Temperature Coefficient: ±100ppm/°C; Category: Resistors; Component Kind: Standard; ComponentLink1Description: http://www.yageo.com/documents/recent/PYu-RC_Group_51_RoHS_L_04.pdf; ComponentLink1URL: http://www.yageo.com/documents/recent/PYu-RC_Group_51_RoHS_L_04.pdf; Composition: Thick Film; Features: Moisture Resistant; Height: 0.022" (0.55mm); Library Name: CustomComponents.SchLib; Manufacturer Part Number: RC0603FR-071KL; Number of Terminations: 2; Operating Temperature: -55°C ~ 155°C; Package / Case: 0603 (1608 Metric); Packaging: Cut Tape (CT); Pricing 1: 1=0.1, 10=0.014, 25=0.01, 100=0.0057, 250=0.00436, 500=0.00348, 1000=0.00257, 2500=0.00223 (USD); Resistance (Ohms): 1k; RoHS: RoHS Compliant; Size / Dimension: 0.063" L x 0.031" W (1.60mm x 0.80mm); Stock 1: 6734836; Supplier 1: Digi-Key; Supplier Device Package: 0603; Supplier Part Number 1: 311-1.00KHRCT-ND; Tolerance: ±1%
R8 = RC0603FR-0747KL: RES SMD 47K OHM 1% 1/10W 0603; Manufacturer: Yageo; Power (Watts): 0.1W, 1/10W; Temperature Coefficient: ±100ppm/°C; Category: Resistors; Component Kind: Standard; ComponentLink1Description: http://www.yageo.com/documents/recent/PYu-RC_Group_51_RoHS_L_04.pdf; ComponentLink1URL: http://www.yageo.com/documents/recent/PYu-RC_Group_51_RoHS_L_04.pdf; Composition: Thick Film; Features: Moisture Resistant; Height: 0.022" (0.55mm); Library Name: CustomComponents.SchLib; Manufacturer Part Number: RC0603FR-0747KL; Number of Terminations: 2; Operating Temperature: -55°C ~ 155°C; Package / Case: 0603 (1608 Metric); Packaging: Cut Tape (CT); Pricing 1: 1=0.1, 10=0.014, 25=0.01, 100=0.0057, 250=0.00436, 500=0.00348, 1000=0.00257, 2500=0.00223 (USD); Resistance (Ohms): 47k; RoHS: RoHS Compliant; Size / Dimension: 0.063" L x 0.031" W (1.60mm x 0.80mm); Stock 1: 930868; Supplier 1: Digi-Key; Supplier Device Package: 0603; Supplier Part Number 1: 311-47.0KHRCT-ND; Tolerance: ±1%
R9-R11 R32-R34 R52 R53 R55-R57 R59 R60 = RC0603FR-073K3L: RES SMD 3.3K OHM 1% 1/10W 0603; Manufacturer: Yageo; Power (Watts): 0.1W, 1/10W; Temperature Coefficient: ±100ppm/°C; Category: Resistors; Component Kind: Standard; ComponentLink1Description: http://www.yageo.com/documents/recent/PYu-RC_Group_51_RoHS_L_04.pdf; ComponentLink1URL: http://www.yageo.com/documents/recent/PYu-RC_Group_51_RoHS_L_04.pdf; Composition: Thick Film; Features: Moisture Resistant; Height: 0.022" (0.55mm); Library Name: CustomComponents.SchLib; Manufacturer Part Number: RC0603FR-073K3L; Number of Terminations: 2; Operating Temperature: -55°C ~ 155°C; Package / Case: 0603 (1608 Metric); Packaging: Cut Tape (CT); Pricing 1: 1=0.1, 10=0.014, 25=0.01, 100=0.0057, 250=0.00436, 500=0.00348, 1000=0.00257, 2500=0.00223 (USD); Resistance (Ohms): 3.3k; RoHS: RoHS Compliant; Size / Dimension: 0.063" L x 0.031" W (1.60mm x 0.80mm); Stock 1: 420019; Supplier 1: Digi-Key; Supplier Device Package: 0603; Supplier Part Number 1: 311-3.30KHRCT-ND; Tolerance: ±1%
SW1 = 219-2MSTR: SWITCH SLIDE DIP SPST 100MA 20V; Manufacturer: CTS Electrocomponents; Actuator Level: Raised; Actuator Type: Slide (Standard); Electrical Life: 2,000 Cycles; Pitch: 0.100" (2.54mm), Full; Voltage Rating: 20VDC; Washable: Yes; Body Material: -; Circuit: SPST; Component Kind: Standard; ComponentLink1Description: https://www.ctscorp.com/wp-content/uploads/219.pdf; ComponentLink1URL: https://www.ctscorp.com/wp-content/uploads/219.pdf; Contact Finish: Gold; Contact Material: Beryllium Copper; Current Rating: 100mA; Features: Epoxy Sealed Terminals, Tape Seal; Height Above Board: 0.170" (4.33mm); Length: 0.258" (6.55mm); Library Name: CustomComponents.SchLib; Mechanical Life: -; Mounting Type: Surface Mount; Operating Temperature: -55°C ~ 85°C; Packaging: Cut Tape (CT); Part Status: Active; Pricing 1: 1=0.73, 10=0.718, 25=0.6764, 50=0.6348, 100=0.6072, 250=0.5796, 500=0.5244 (USD); RoHS: RoHS Compliant; Stock: 9967; Supplier 1: Digi-Key; Supplier Part Number 1: CT3114CT-ND; Termination Style: Gull Wing
TP1-TP5 = TESTPOINT: SMD test pin; Library Name: PBL - Test Points.SCHLIB
U1 = SN65HVD232D: Manufacturer: Texas Instruments; Data Rate: 1Mbps; Duplex: Half; Number of Drivers/Receivers: 1/1; Protocol: CAN; Voltage - Supply: 3 V ~ 3.6 V; Category: Integrated Circuits (ICs); Component Kind: Standard; ComponentLink1Description: http://www.ti.com/lit/ds/symlink/sn65hvd230.pdf; ComponentLink1URL: http://www.ti.com/lit/ds/symlink/sn65hvd230.pdf; Library Name: CustomComponents.SchLib; Mounting Type: Surface Mount; Operating Temperature: -40°C ~ 85°C; Package / Case: 8-SOIC (0.154", 3.90mm Width); Packaging: Tape & Reel (TR); Part Status: Active; Pricing 1: 2500=0.84375 (USD); Pricing 2: 1=2.05, 10=1.842, 100=1.4809, 500=1.21668, 1000=1.00811 (USD); RoHS: RoHS Compliant; Stock: 5000; Supplier 1: Digi-Key; Supplier 2: Digi-Key; Supplier Device Package: 8-SOIC; Supplier Part Number 1: 296-26344-2-ND; Supplier Part Number 2: 296-26344-1-ND; Type: Transceiver
U2 = STM32F405RGT6: ARM Cortex-M4 32-bit MCU+FPU, 210 DMIPS, 1024 kB Flash, 192 kB Internal RAM, 51 I/Os, 64-pin LQFP, -40 to 85 degC, Tray; Manufacturer: STMicroelectronics; D/A Converter: 2x12-bit; FLASH Size (Prog) (kB): 1024; I/Os (High Current): 51; Internal RAM Size (kB): 192; Operating Frequency(F) (Processor speed) (MHz): 168; Supply Voltage(Vcc) max (V): 3.6; Supply Voltage(Vcc) min (V): 1.8; Component Kind: Standard; ComponentLink1Description: Manufacturer URL; ComponentLink1URL: http://www.st.com/web/en/home.html; ComponentLink2Description: Datasheet; ComponentLink2URL: http://www.st.com/st-web-ui/static/active/en/resource/technical/document/datasheet/DM00037051.pdf; ComponentLink3Description: Package Specification; ComponentLink3URL: http://www.st.com/st-web-ui/static/active/en/resource/technical/document/datasheet/DM00037051.pdf; Core: ARM Cortex-M4; DatasheetVersion: Rev. 4; Library Name: STMicroelectronics STM32 F4.IntLib; Mounting Technology: Surface Mount; PackageDescription: 64-Pin Low Profile Quad Flat Package, 10 x 10 mm Body, 0.5 mm Pitch; PackageReference: LQFP64; PackageVersion: Rev. 4, 06/2013; Packing: Tray; PartNumber: STM32F405RGT6; Supplier 1: Digi-Key; Supplier 2: Farnell; Supplier 3: Newark; Supplier 4: Mouser; Supplier Part Number 1: 497-11767-ND; Supplier Part Number 2: 2064363; Supplier Part Number 3: 73T2329; Supplier Part Number 4: 511-STM32F405RGT6
U3 = AZ1117EH-3.3TRG1: IC REG LDO 3.3V 1A SOT223; Manufacturer: Diodes Incorporated; Regulator Topology: Positive Fixed; Voltage - Dropout (Typical): 1.1V @ 1A; Voltage - Input: Up to 13V; Category: Integrated Circuits (ICs); Component Kind: Standard; ComponentLink1Description: http://www.diodes.com/assets/Datasheets/AZ1117E.pdf; ComponentLink1URL: http://www.diodes.com/assets/Datasheets/AZ1117E.pdf; Current - Limit (Min): -; Current - Output: 1A; Library Name: CustomComponents.SchLib; Mounting Type: Surface Mount; Operating Temperature: -40°C ~ 125°C; Package / Case: TO-261-4, TO-261AA; Packaging: Cut Tape (CT); Part Status: Active; Pricing 1: 1=0.43, 10=0.321, 100=0.1815, 500=0.12022, 1000=0.09216 (USD); RoHS: RoHS Compliant; Stock 1: 22748; Supplier 1: Digi-Key; Supplier Device Package: SOT-223; Supplier Part Number 1: AZ1117EH-3.3TRG1DICT-ND; Voltage - Output: 3.3V
U8 = LP5907MFX-3.3/NOPB: IC REG LDO 3.3V 0.25A SOT23-5; Manufacturer: Texas Instruments; Current - Output: 250mA; Regulator Topology: Positive Fixed; Voltage - Input: Up to 5.5V; Category: Integrated Circuits (ICs); Component Kind: Standard; ComponentLink1Description: http://www.ti.com/lit/ds/symlink/lp5907.pdf; ComponentLink1URL: http://www.ti.com/lit/ds/symlink/lp5907.pdf; Current - Limit (Min): -; Library Name: CustomComponents.SchLib; Mounting Type: Surface Mount; Operating Temperature: -40°C ~ 125°C; Package / Case: SC-74A, SOT-753; Packaging: Cut Tape (CT); Part Status: Active; Pricing 1: 1=0.57, 10=0.487, 100=0.3634, 500=0.28552, 1000=0.22063 (USD); RoHS: RoHS Compliant; Stock 1: 39845; Supplier 1: Digi-Key; Supplier Device Package: SOT-23; Supplier Part Number 1: 296-38557-1-ND; Voltage - Dropout (Typical): -; Voltage - Output: 3.3V
XT1 = ABM3B-8.000MHZ-10-1-U-T: Crystal 8MHz ±10ppm 10pF 200 Ohm -10°C ~ 60°C Surface Mount 4-SMD, No Lead (DFN, LCC); Manufacturer: Abracon LLC; Operating Mode: Fundamental; Category: Crystals, Oscillators, Resonators; Component Kind: Standard; ComponentLink1Description: http://www.abracon.com/Resonators/abm3b.pdf; ComponentLink1URL: http://www.abracon.com/Resonators/abm3b.pdf; Frequency Stability: ±10ppm; Frequency Tolerance: ±10ppm; Height - Seated (Max): 0.043" (1.10mm); Library Name: CustomComponents.SchLib; Manufacturer Part Number: ABM3B-8.000MHZ-10-1-U-T; Mounting Type: Surface Mount; Operating Temperature: -10°C ~ 60°C; Package / Case: 4-SMD, No Lead; Packaging: Cut Tape (CT); Part Status: Active; Pricing 1: 1=0.69, 10=0.578, 50=0.5198, 100=0.462, 500=0.4389 (USD); Pricing 2: 1000=0.336, 3000=0.315, 5000=0.3045, 10000=0.294 (USD); Ratings: -; RoHS: RoHS Compliant; Size / Dimension: 0.197" L x 0.126" W (5.00mm x 3.20mm); Stock: 35437; Supplier 1: Digi-Key; Supplier 2: Digi-Key; Supplier Part Number 1: 535-9721-1-ND; Supplier Part Number 2: 535-9721-2-ND; Type: MHz Crystal; Value: 8 MHz
